# Altium schematic sheet 硬件大作业.SchDoc
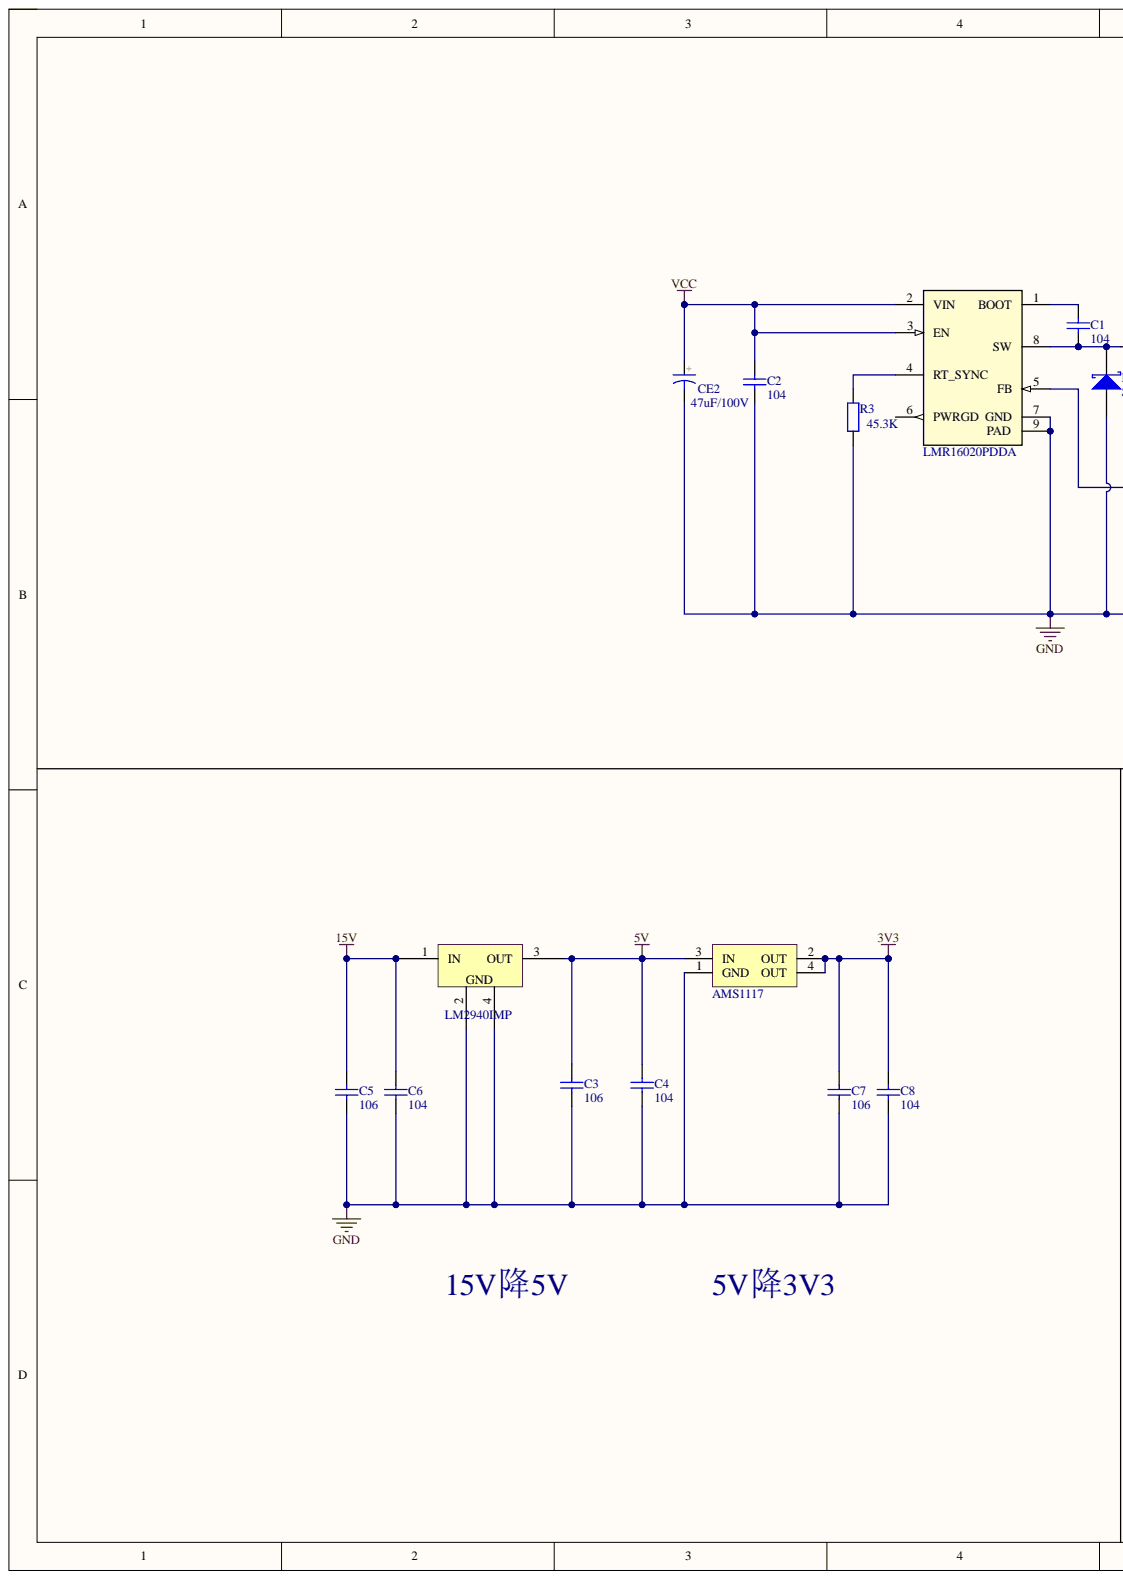
[diagram p0: 硬件大作业.SchDoc, left]
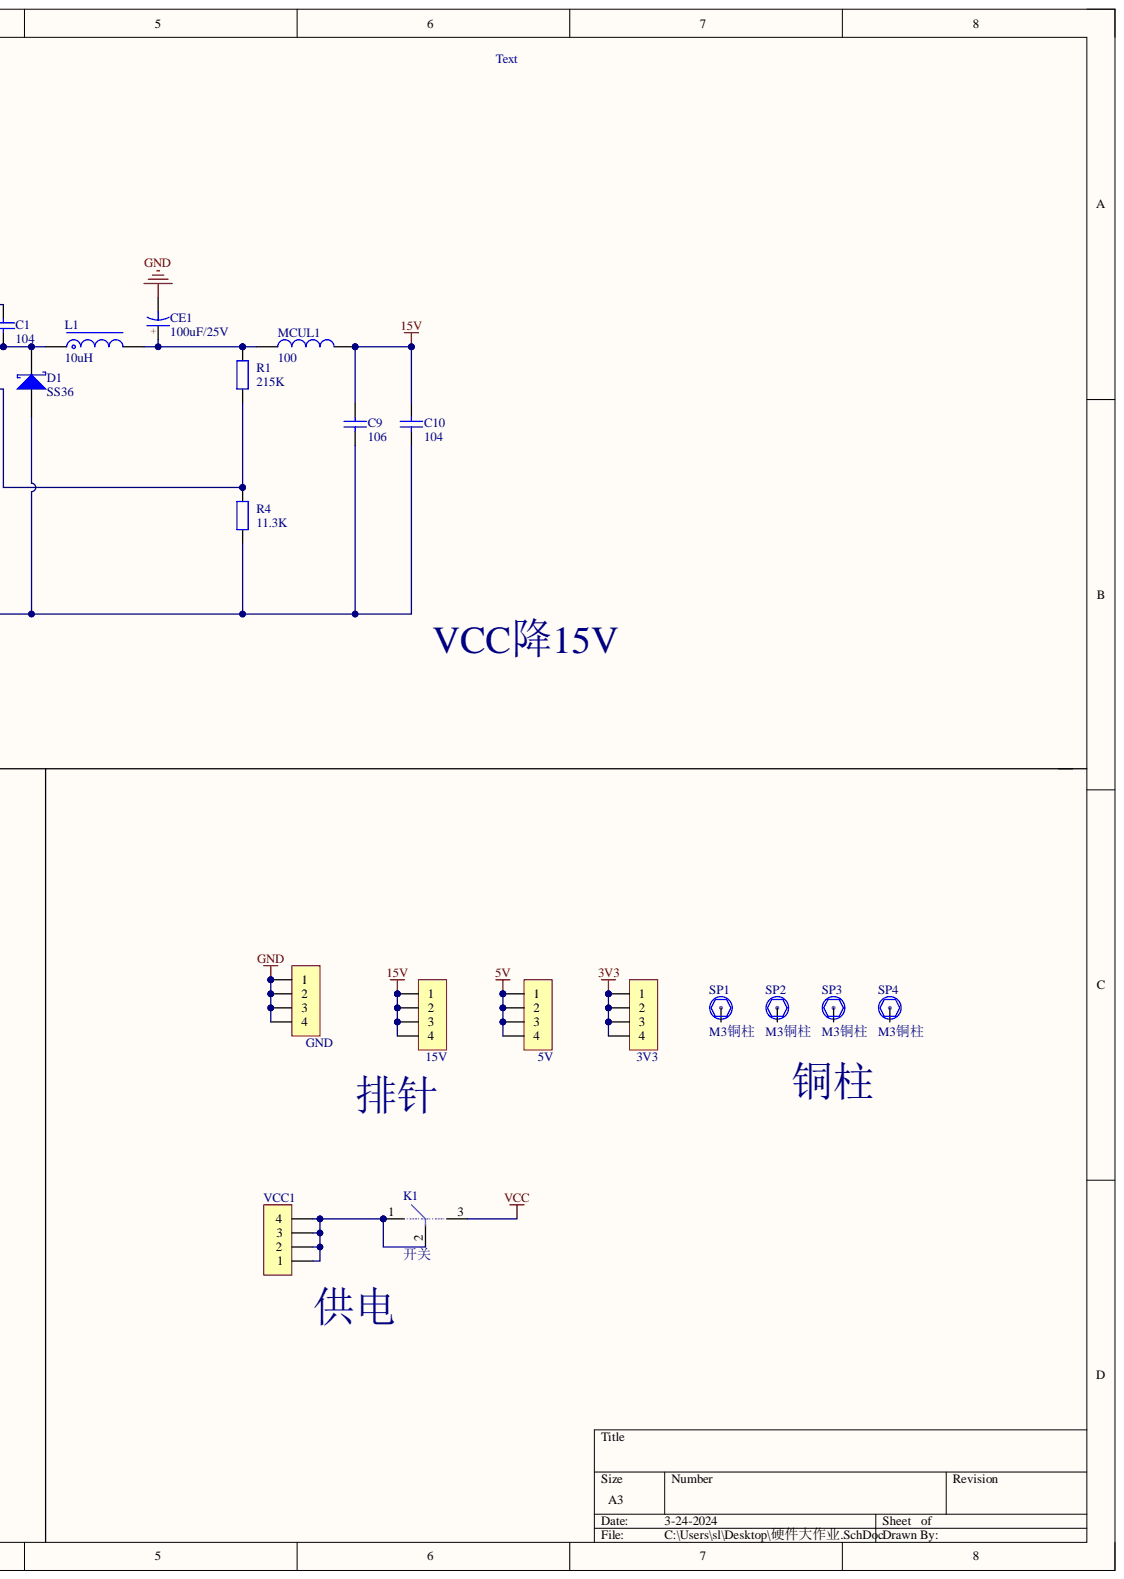
[diagram p1: 硬件大作业.SchDoc, right]

## Parts
3V3  (no value)  [HDR2.54-LI-4P]
5V  (no value)  [HDR2.54-LI-4P]
15V  (no value)  [HDR2.54-LI-4P]
C1  104  [C0805_L]
C2  104  [C0805_L]
C3  106  [C0805_M]
C4  104  [C0805_M]
C5  106  [C0805_M]
C6  104  [C0805_M]
C7  106  [C0805_M]
C8  104  [C0805_M]
C9  106  [C0805_L]
C10  104  [C0805_L]
CE1  100uF/25V  [CAP 2.5*6.3*11.2]
CE2  47uF/100V  [CAP 2.5*6.3*11.2]
D1  SS36  [SMA]
GND  (no value)  [HDR2.54-LI-4P]
K1  开关  [开关]
L1  10uH  [L-SMD-0630]
MCUL1  100  [L0805]
R1  215K  [R0805_L]
R3  45.3K  [R0805_L]
R4  11.3K  [R0805_L]
SP1  M3铜柱  [M3x4_N]
SP2  M3铜柱  [M3x4_N]
SP3  M3铜柱  [M3x4_N]
SP4  M3铜柱  [M3x4_N]
VCC1  (no value)  [HDR2.54-LI-4P]
  LM2940IMP  [SOT223_M]
  AMS1117  [AMS1117]
  LMR16020PDDA  [DDA0008E_L]

## Nets
3V3: 3V3.1 3V3.2 3V3.3 3V3.4 C7.2 C8.2 .2 .4
5V: 5V.1 5V.2 5V.3 5V.4 C3.2 C4.2 .3
15V: 15V.1 15V.2 15V.3 15V.4 C5.2 C6.2 C9.2 C10.2 MCUL1.2 .1
GND: C2.1 C3.1 C4.1 C5.1 C6.1 C7.1 C8.1 C9.1 C10.1 CE1.2 CE2.2 D1.1 GND.1 GND.2 GND.3 GND.4 R3.1 R4.1 .1 .2 .4 .7(GND) .9(PAD)
Net_1: C1.2 .1
Net_4: R3.2 .4
Net_5: R1.1 R4.2 .5(FB)
Net_6: .6(PWRGD)
Net_8: C1.1 D1.2 L1.1 .8(SW)
VCC: C2.2 CE2.1 K1.3 .2 .3
NetCE1_1: CE1.1 L1.2 MCUL1.1 R1.2
NetK1_1: K1.1 K1.2 VCC1.1 VCC1.2 VCC1.3 VCC1.4

Not connected: SP1 1; SP2 1; SP3 1; SP4 1

## Library data of the parts
3V3 5V 15V GND VCC1 = 1*4pin排针排母: Component Kind: Standard; Description: 1*4pin排针排母; Library Name: 实验室原理图库21.5.2.SchLib
C1-C10 = C: 贴片电容; BOM名称: 无极性贴片电容; 封装库来自淘宝卖家ID：黄晶宝石: 其他店铺购入均为盗版假冒封装库，请立即申请退款; 联系卖家，免费查找封装: 唯一出售店铺网址https://shop463601444.taobao.com; Component Kind: Standard
  C1 C2 C9 C10: Library Name: 0.1 - 电阻-电容-电感-电位器.SchLib
  C3-C8: Library Name: 实验室原理图库21.5.2.SchLib
CE1 CE2 = CE: 直插电解电容; 封装库来自淘宝卖家ID：黄晶宝石: 其他店铺购入均为盗版假冒封装库，请立即申请退款; 联系卖家，免费查找封装: 唯一出售店铺网址https://shop463601444.taobao.com; BOM名称: 直插电解电容; Component Kind: Standard; Library Name: 0.1 - 电阻-电容-电感-电位器.SchLib
D1 = SS36: 肖特基二极管; 属性: 60V/3A; 联系卖家，免费查找封装: 唯一出售店铺网址https://shop463601444.taobao.com; BOM名称: 肖特基二极管; Component Kind: Standard; Library Name: 0.2 - 二极管-TVS-整流桥.SchLib
L1 = L-Molding Choke: 一体成型电感; 封装库来自淘宝卖家ID：黄晶宝石: 其他店铺购入均为盗版假冒封装库，请立即申请退款; 联系卖家，免费查找封装: 唯一出售店铺网址https://shop463601444.taobao.com; BOM名称: 一体成型电感; Component Kind: Standard; Library Name: 0.1 - 电阻-电容-电感-电位器.SchLib
MCUL1 = L: 贴片小功率电感; BOM名称: 小功率贴片电感; 封装库来自淘宝卖家ID：黄晶宝石: 其他店铺购入均为盗版假冒封装库，请立即申请退款; 联系卖家，免费查找封装: 唯一出售店铺网址https://shop463601444.taobao.com; Component Kind: Standard; Library Name: 0.1 - 电阻-电容-电感-电位器.SchLib
R1 R3 R4 = R: 贴片电阻; 封装库来自淘宝卖家ID：黄晶宝石: 其他店铺购入均为盗版假冒封装库，请立即申请退款; 联系卖家，免费查找封装: 唯一出售店铺网址https://shop463601444.taobao.com; BOM名称: 贴片电阻; Component Kind: Standard; Library Name: 实验室原理图库21.5.2.SchLib
SP1-SP4 = 铜柱大焊盘: 铜柱; 联系卖家，免费查找封装: 唯一出售店铺网址https://shop463601444.taobao.com; BOM名称: 铜柱; Component Kind: Standard; Library Name: 0.7 - 常用按键-开关-蜂鸣器-电池-测试点.SchLib
 = LM2940IMP: 贴片-低压差线性稳压器; 封装库来自淘宝卖家 ID：黄晶宝石: 其他店铺购入均为盗版，请立即申请退款，本店现金奖励5~20元; 联系卖家 免费查找封装: 唯一出售店铺网址https://shop463601444.taobao.com; Component Kind: Standard; Library Name: 1.1 - 电源类芯片.SchLib
 = LMR16020PDDA: No Description Available; Manufacturer: Texas Instruments; PartNumber: LMR16020PDDAR; Component Kind: Standard; RefDes: RefDes; Type: DEV; Value: Value; Copyright: Copyright (C) 2018 Accelerated Designs. All rights reserved; Library Name: 实验室原理图库21.5.2.SchLib
K1 = 开关: Component Kind: Standard; Library Name: 实验室原理图库21.5.2.SchLib
 = AMS1117: Component Kind: Standard; Library Name: 实验室原理图库21.5.2.SchLib
